# Altium schematic sheet MCU.SchDoc
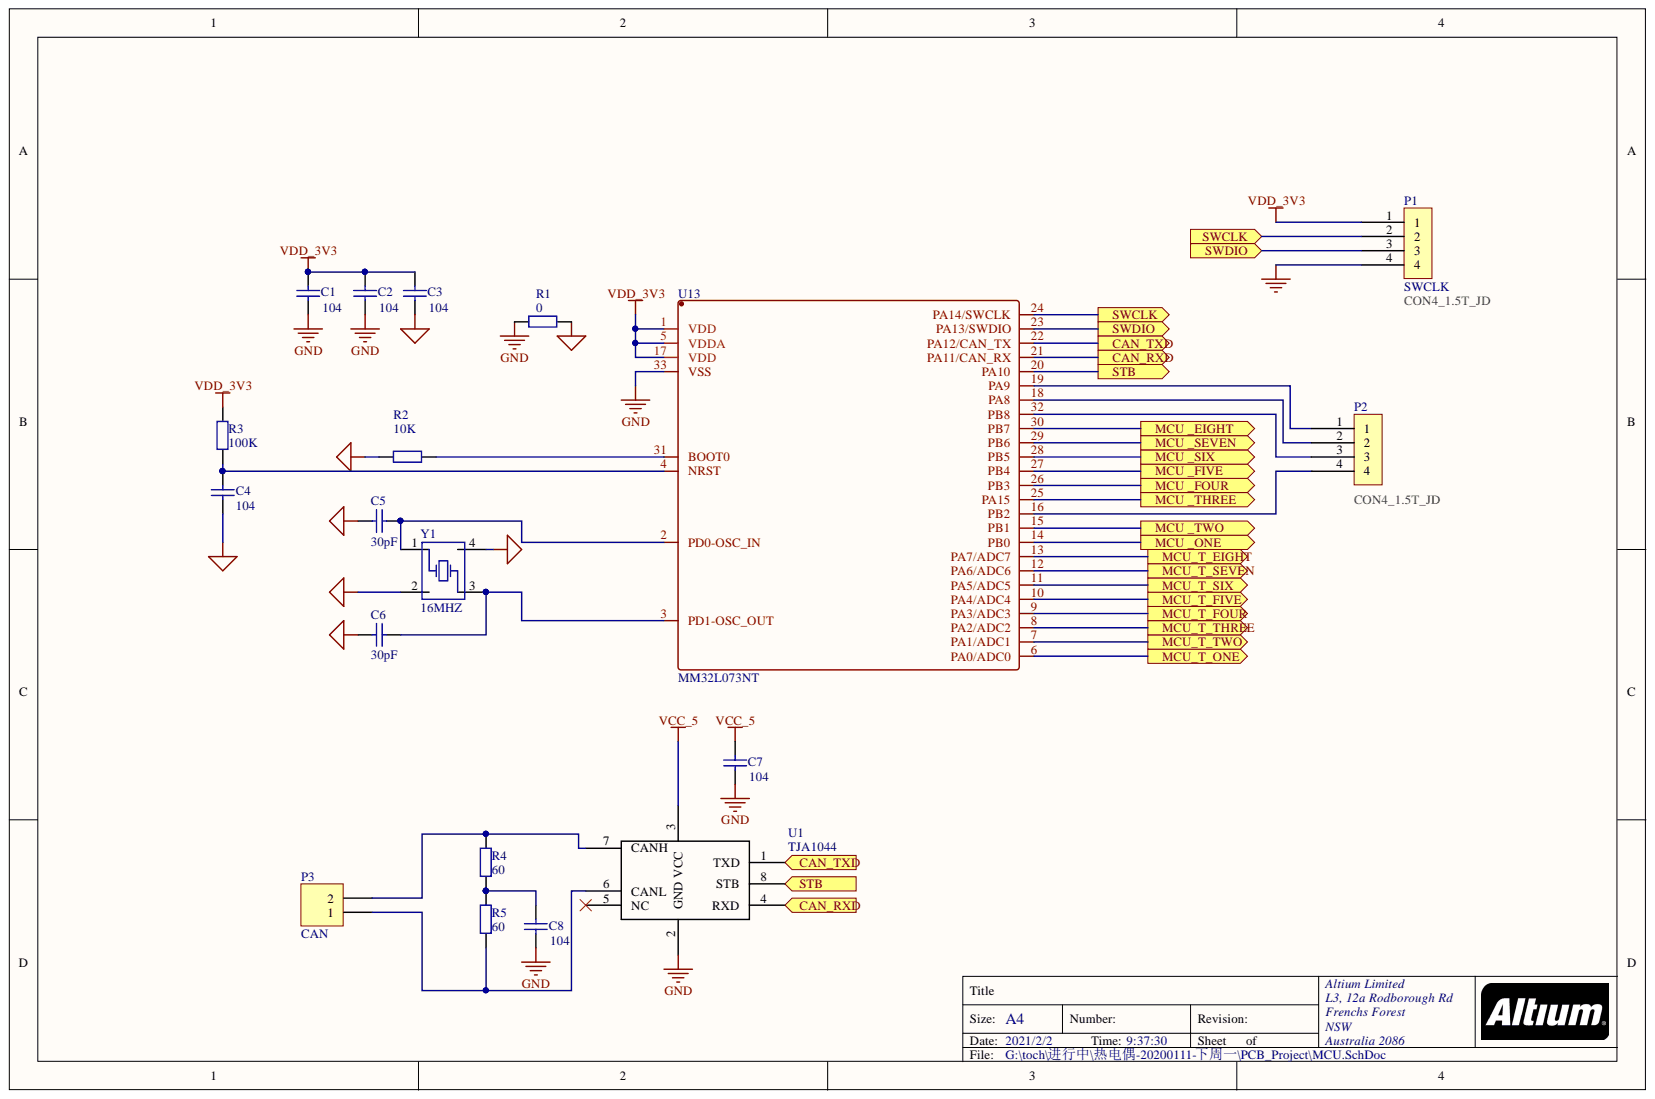
[diagram p0: MCU.SchDoc]

## Parts
C1  104  [0603_C]
C2  104  [0603_C]
C3  104  [0603_C]
C4  104  [0603_C]
C5  30pF  [0603_C]
C6  30pF  [0603_C]
C7  104  [0603_C]
C8  104  [0603_C]
P1  SWCLK  [CON1.5_4Opin]
P2  (no value)  [CON1.5_4Opin]
P3  CAN  [CON1.5_2pin]
R1  0  [0603_R]
R2  10K  [0603_R]
R3  100K  [0603_R]
R4  60  [0603_R]
R5  60  [0603_R]
U1  TJA1044  [SOP-8_150mil]
U13  MM32L073NT  [QFN_32]
Y1  16MHZ  [SMD-3225_4P]

## Ports
CAN_RXD, CAN_TXD, MCU _EIGHT, MCU _FIVE, MCU _FOUR, MCU _ONE, MCU _SEVEN, MCU _SIX, MCU _THREE, MCU _TWO, MCU_T_EIGHT, MCU_T_FIVE, MCU_T_FOUR, MCU_T_ONE, MCU_T_SEVEN, MCU_T_SIX, MCU_T_THREE, MCU_T_TWO, STB, SWCLK, SWDIO

## Nets
GND: C1.2 C2.2 C7.2 C8.2 P1.4 R1.1 U1.2(GND) U13.33(VSS)
SGND: C3.2 C4.1 C5.2 C6.2 R1.2 R2.1 Y1.2 Y1.4
VCC_5: C7.1 U1.3(VCC)
VDD_3V3: C1.1 C2.1 C3.1 P1.1 R3.2 U13.1(VDD) U13.5(VDDA) U13.17(VDD)
CAN_RXD: U1.4(RXD) U13.21(PA11/CAN_RX) ; port CAN_RXD
CAN_TXD: U1.1(TXD) U13.22(PA12/CAN_TX) ; port CAN_TXD
MCU _EIGHT: U13.30(PB7) ; port MCU _EIGHT
MCU _FIVE: U13.27(PB4) ; port MCU _FIVE
MCU _FOUR: U13.26(PB3) ; port MCU _FOUR
MCU _ONE: U13.14(PB0) ; port MCU _ONE
MCU _SEVEN: U13.29(PB6) ; port MCU _SEVEN
MCU _SIX: U13.28(PB5) ; port MCU _SIX
MCU _THREE: U13.25(PA15) ; port MCU _THREE
MCU _TWO: U13.15(PB1) ; port MCU _TWO
MCU_T_EIGHT: U13.13(PA7/ADC7) ; port MCU_T_EIGHT
MCU_T_FIVE: U13.10(PA4/ADC4) ; port MCU_T_FIVE
MCU_T_FOUR: U13.9(PA3/ADC3) ; port MCU_T_FOUR
MCU_T_ONE: U13.6(PA0/ADC0) ; port MCU_T_ONE
MCU_T_SEVEN: U13.12(PA6/ADC6) ; port MCU_T_SEVEN
MCU_T_SIX: U13.11(PA5/ADC5) ; port MCU_T_SIX
MCU_T_THREE: U13.8(PA2/ADC2) ; port MCU_T_THREE
MCU_T_TWO: U13.7(PA1/ADC1) ; port MCU_T_TWO
STB: U1.8(STB) U13.20(PA10) ; port STB
SWCLK: P1.2 U13.24(PA14/SWCLK) ; port SWCLK
SWDIO: P1.3 U13.23(PA13/SWDIO) ; port SWDIO
NetC4_2: C4.2 R3.1 U13.4(NRST)
NetC5_1: C5.1 U13.2(PD0-OSC_IN) Y1.1
NetC6_1: C6.1 U13.3(PD1-OSC_OUT) Y1.3
NetC8_1: C8.1 R4.1 R5.2
NetP2_1: P2.1 U13.19(PA9)
NetP2_2: P2.2 U13.18(PA8)
NetP2_3: P2.3 U13.32(PB8)
NetP2_4: P2.4 U13.16(PB2)
NetP3_1: P3.1 R5.1 U1.6(CANL)
NetP3_2: P3.2 R4.2 U1.7(CANH)
NetR2_2: R2.2 U13.31(BOOT0)

Not connected: U1 5(NC)

## Library data of the parts
C1-C4 C7 C8 = 0603 1uF (105) 10% 50V: 贴片电容; Component Kind: Standard; ComponentLink1Description: 供应商链接; ComponentLink1URL: http://www.szlcsc.com/product/details_16531.html; Library Name: Miscellaneous Devices LC.IntLib; Package: 0603; Supplier: LC; SuppliersPartNumber: C15849
C5 C6 = 0603 12pF (120) 5% 50V: 贴片电容; Component Kind: Standard; ComponentLink1Description: 供应商链接; ComponentLink1URL: http://www.szlcsc.com/product/details_39506.html; Library Name: Miscellaneous Devices LC.IntLib; Package: 0603; Supplier: LC; SuppliersPartNumber: C38523
P1 P2 = SIP_4: CON4_1.5T_JD; Component Kind: Standard; Parameter 1: CON4_1.5T_JD; Library Name: CONNECTER.SchLib
P3 = Header 2: Header, 2-Pin; Component Kind: Standard; LatestRevisionDate: 17-Jul-2002; LatestRevisionNote: Re-released for DXP Platform.; Library Name: Miscellaneous Connectors.IntLib; Signal Integrity: Connector; Publisher: Altium Limited
R1 R2 R4 R5 = 0603 10KΩ (1002) 1%: 贴片电阻; Component Kind: Standard; ComponentLink1Description: 供应商链接; ComponentLink1URL: http://www.szlcsc.com/product/details_26547.html; Library Name: Miscellaneous Devices LC.IntLib; Package: 0603; Supplier: LC; SuppliersPartNumber: C25804
R3 = 0603 100KΩ (1003) 1%: 贴片电阻; Component Kind: Standard; ComponentLink1Description: 供应商链接; ComponentLink1URL: http://www.szlcsc.com/product/details_26546.html; Library Name: Miscellaneous Devices LC.IntLib; Package: 0603; Supplier: LC; SuppliersPartNumber: C25803
U1 = TAJ1044: Component Kind: Standard; Library Name: Schlib1.SchLib
Y1 = SMD-3225_4P  16MHZ 9PF 10PPM: 贴片晶振; Component Kind: Standard; ComponentLink1Description: 供应商链接; ComponentLink1URL: http://www.szlcsc.com/product/details_14393.html; Library Name: Miscellaneous Devices LC.IntLib; Package: SMD-3225_4P; Supplier: LC; SuppliersPartNumber: C13738
U13 = MM32L073NT: Component Kind: Standard; Library Name: Schlib1.SchLib
